# Altium schematic sheet Arak-ADC.SchDoc
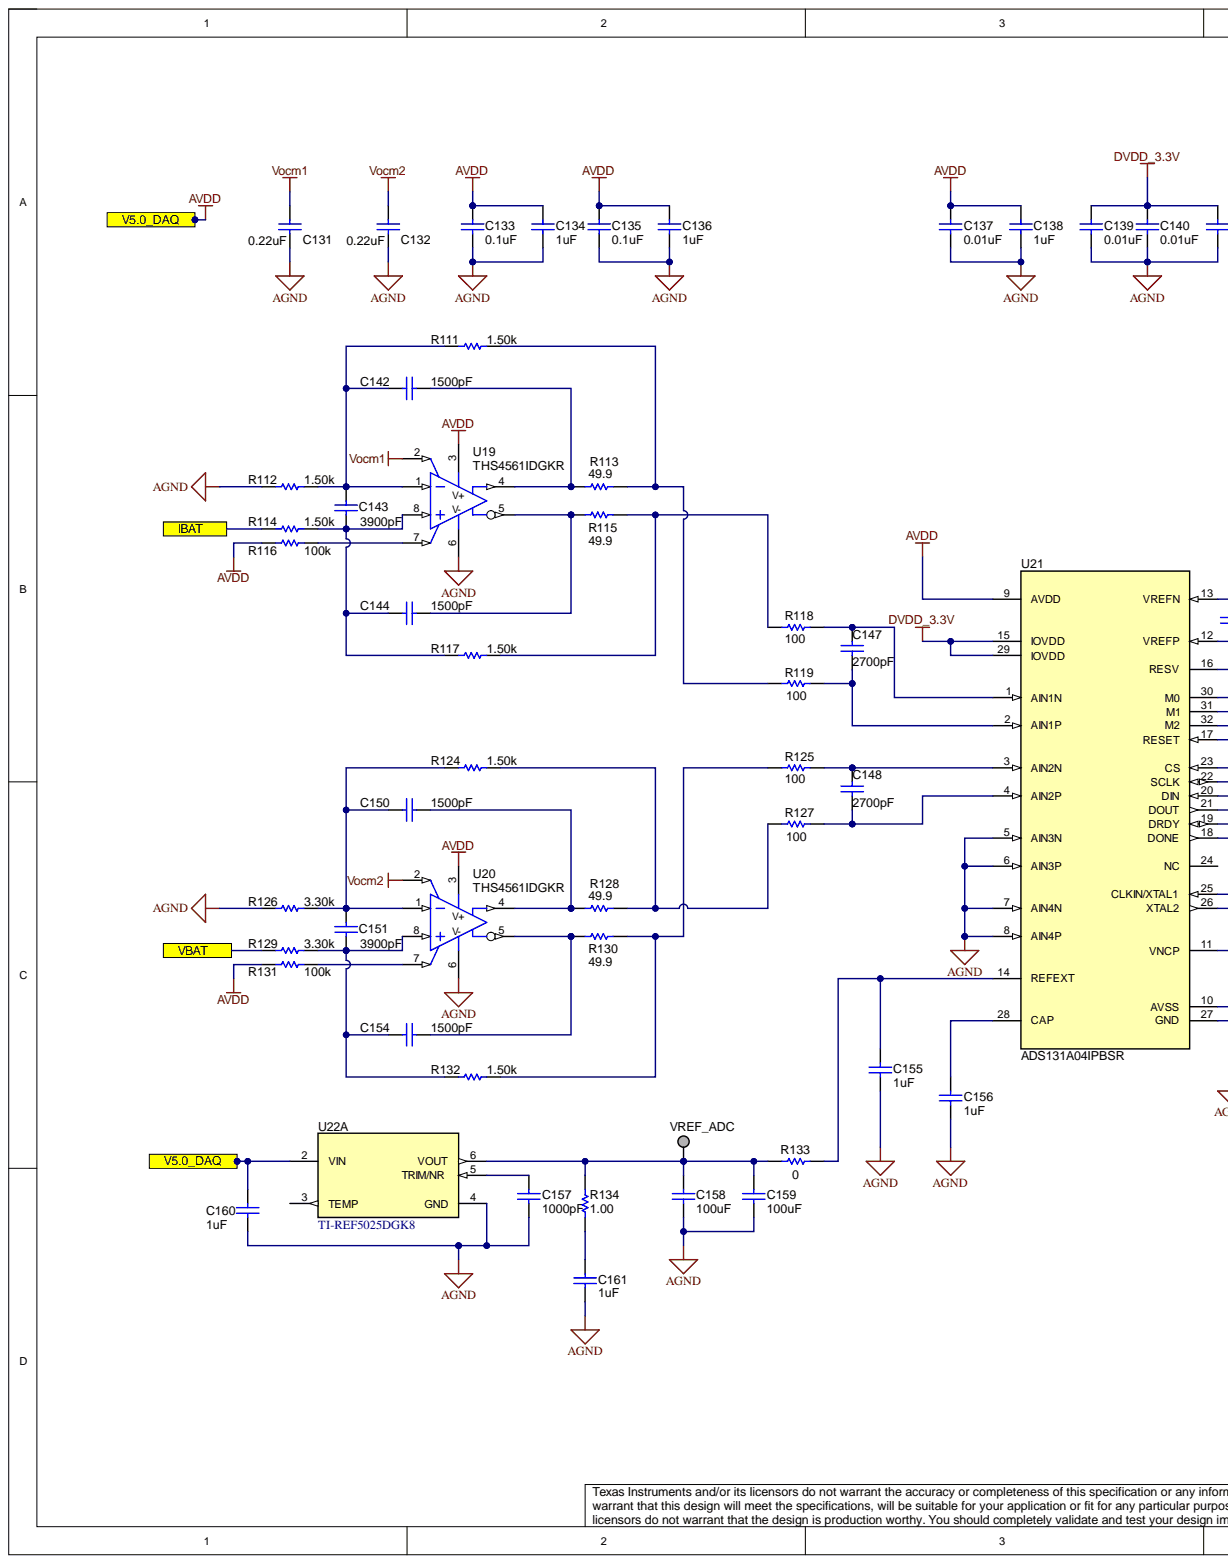
[diagram p0: Arak-ADC.SchDoc, left]
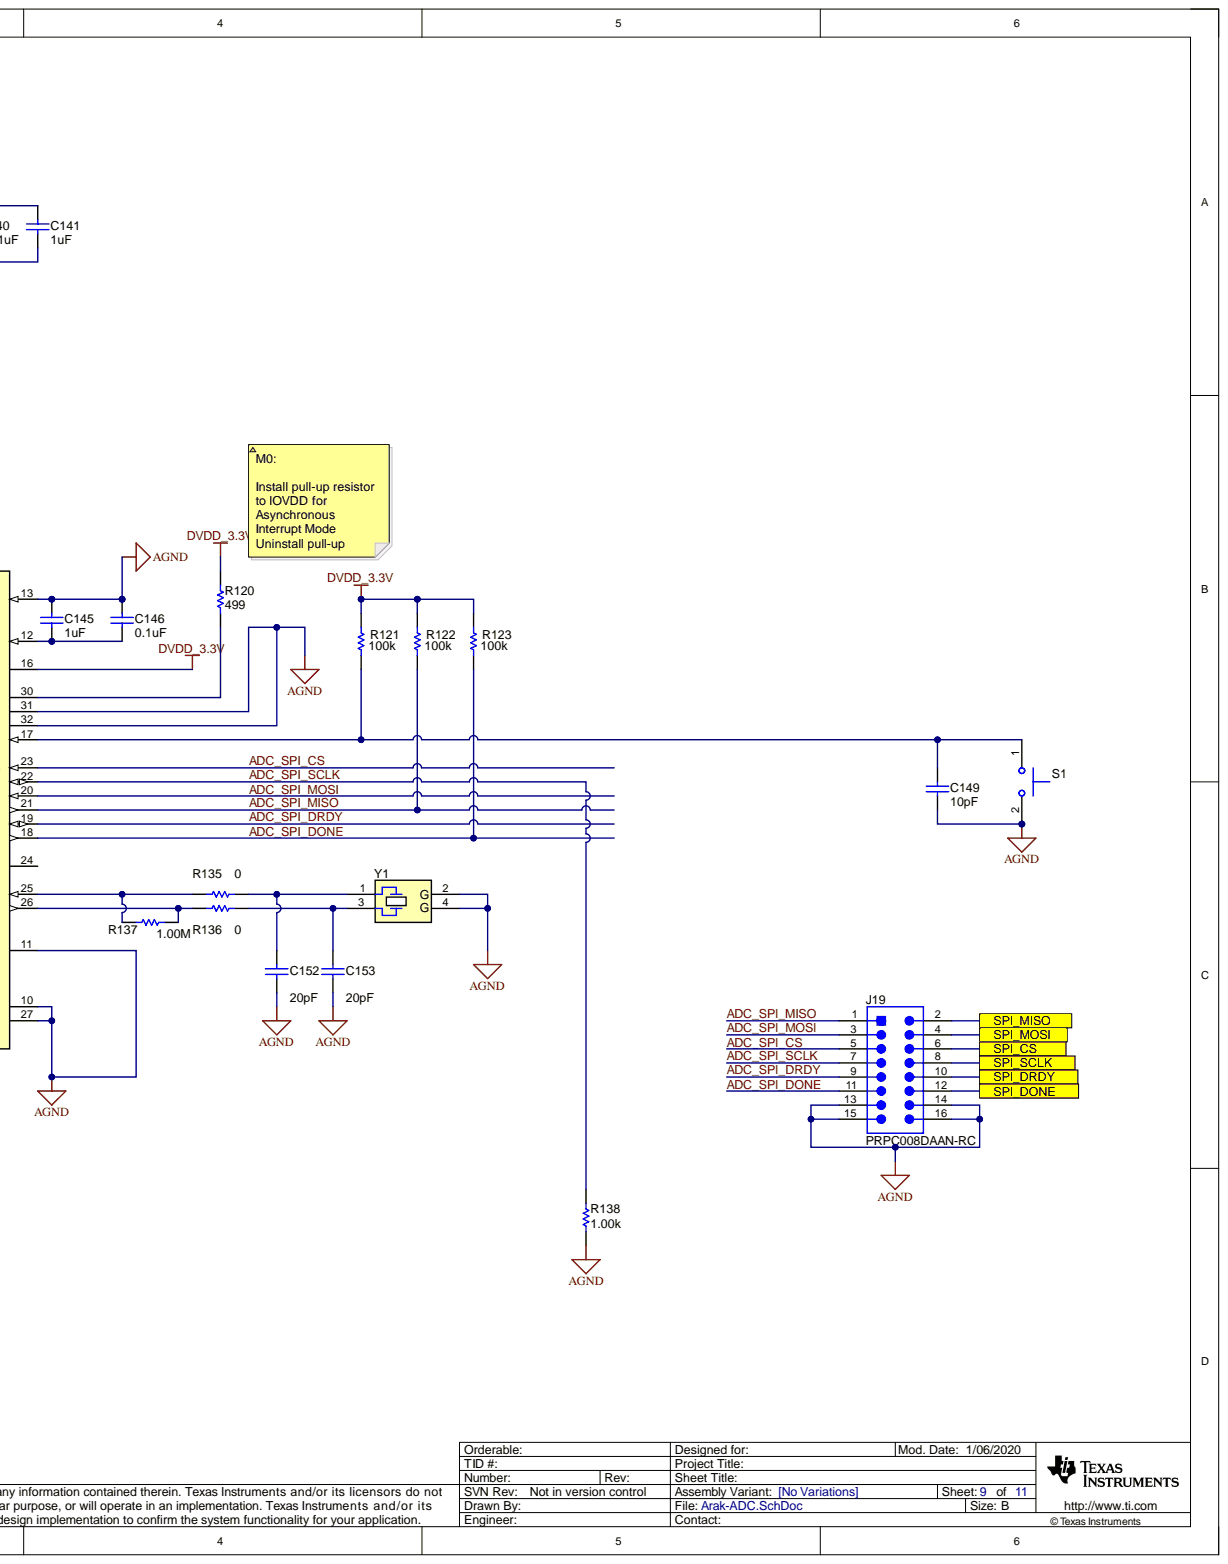
[diagram p1: Arak-ADC.SchDoc, right]

## Parts
C131  value 0.22uF  [0603]  (hidden comment: Capacitor)
C132  value 0.22uF  [0603]  (hidden comment: Capacitor)
C133  value 0.1uF  [0603]  (hidden comment: Capacitor)
C134  value 1uF  [0603]  (hidden comment: Capacitor)
C135  value 0.1uF  [0603]  (hidden comment: Capacitor)
C136  value 1uF  [0603]  (hidden comment: Capacitor)
C137  value 0.01uF  [0603]  (hidden comment: Capacitor)
C138  value 1uF  [0603]  (hidden comment: Capacitor)
C139  value 0.01uF  [0603]  (hidden comment: Capacitor)
C140  value 0.01uF  [0603]  (hidden comment: Capacitor)
C141  value 1uF  [0603]  (hidden comment: Capacitor)
C142  value 1500pF  [0603]  (hidden comment: Capacitor)
C143  value 3900pF  [0603]  (hidden comment: GRM188R72A392KA01D)
C144  value 1500pF  [0603]  (hidden comment: Capacitor)
C145  value 1uF  [0603]  (hidden comment: Capacitor)
C146  value 0.1uF  [0603]  (hidden comment: Capacitor)
C147  value 2700pF  [0603]  (hidden comment: Capacitor)
C148  value 2700pF  [0603]  (hidden comment: Capacitor)
C149  value 10pF  Cap_F: 10pF  [0603]  (hidden comment: Capacitor)
C150  value 1500pF  [0603]  (hidden comment: Capacitor)
C151  value 3900pF  [0603]  (hidden comment: GRM188R72A392KA01D)
C152  value 20pF  Cap_F: 20pF  [0603]  (hidden comment: GRM1885C1H200JA01D)
C153  value 20pF  Cap_F: 20pF  [0603]  (hidden comment: GRM1885C1H200JA01D)
C154  value 1500pF  [0603]  (hidden comment: Capacitor)
C155  value 1uF  [0603]  (hidden comment: Capacitor)
C156  value 1uF  [0603]  (hidden comment: Capacitor)
C157  value 1000pF  [0603]  (hidden comment: Capacitor)
C158  value 100uF  [1206_190]  (hidden comment: Capacitor)
C159  value 100uF  [1206_190]  (hidden comment: Capacitor)
C160  value 1uF  [0603]  (hidden comment: Capacitor)
C161  value 1uF  [0603]  (hidden comment: Capacitor)
J19  PRPC008DAAN-RC  [Sullins_xxxC008DAAN]
R111  value 1.50k  [0603]  (hidden comment: RC0603FR-071K5L)
R112  value 1.50k  [0603]  (hidden comment: RC0603FR-071K5L)
R113  value 49.9  Res_ohm: 49.9  [0603]  (hidden comment: Resistor)
R114  value 1.50k  [0603]  (hidden comment: RC0603FR-071K5L)
R115  value 49.9  Res_ohm: 49.9  [0603]  (hidden comment: Resistor)
R116  value 100k  Res_ohm: 100k  [0603]  (hidden comment: Resistor)
R117  value 1.50k  [0603]  (hidden comment: RC0603FR-071K5L)
R118  value 100  [0603]  (hidden comment: Resistor)
R119  value 100  [0603]  (hidden comment: Resistor)
R120  value 499  [0603]  (hidden comment: Resistor)
R121  value 100k  Res_ohm: 100k  [0603]  (hidden comment: Resistor)
R122  value 100k  Res_ohm: 100k  [0603]  (hidden comment: Resistor)
R123  value 100k  Res_ohm: 100k  [0603]  (hidden comment: Resistor)
R124  value 1.50k  [0603]  (hidden comment: RC0603FR-071K5L)
R125  value 100  [0603]  (hidden comment: Resistor)
R126  value 3.30k  [0603]  (hidden comment: RC0603FR-073K3L)
R127  value 100  [0603]  (hidden comment: Resistor)
R128  value 49.9  Res_ohm: 49.9  [0603]  (hidden comment: Resistor)
R129  value 3.30k  [0603]  (hidden comment: RC0603FR-073K3L)
R130  value 49.9  Res_ohm: 49.9  [0603]  (hidden comment: Resistor)
R131  value 100k  Res_ohm: 100k  [0603]  (hidden comment: Resistor)
R132  value 1.50k  [0603]  (hidden comment: RC0603FR-071K5L)
R133  value 0  [0603]  (hidden comment: Resistor)
R134  value 1.00  [0603]  (hidden comment: Resistor)
R135  value 0  [0603]  (hidden comment: Resistor)
R136  value 0  [0603]  (hidden comment: Resistor)
R137  ValueDisplayed: 1.00M  [0603]  (hidden comment: Resistor)
R138  value 1.00k  [0603]  (hidden comment: Resistor)
S1  Switch_PushButton  [SW_TL1015AF160QG]  (value not drawn)
U19  THS4561IDGKR  [DGK0008A_N]
U20  THS4561IDGKR  [DGK0008A_N]
U21  ADS131A04IPBSR  [PBS0032A_L]
U22  TI-REF5025DGK8  [DGK0008A_N]  (part A)
VREF_ADC  Pogo Pad  [PogoPad]  (value not drawn)  (no BOM)
Y1  XTAL_4P_13_G24  [CTS_407]  (value not drawn)

## Ports
IBAT, SPI_CS, SPI_DONE, SPI_DRDY, SPI_MISO, SPI_MOSI, SPI_SCLK, V5.0_DAQ, VBAT

## Nets
AGND: C131.2 C132.2 C133.2 C134.2 C135.2 C136.2 C137.2 C138.2 C139.2 C140.2 C141.2 C145.1 C146.1 C149.1 C152.2 C153.2 C155.2 C156.2 C157.2 C158.2 C159.2 C160.2 C161.2 J19.13 J19.14 J19.15 J19.16 R112.1 R126.1 R138.1 S1.2 U19.6(V-) U20.6(V-) U21.5(AIN3N) U21.6(AIN3P) U21.7(AIN4N) U21.8(AIN4P) U21.10(AVSS) U21.11(VNCP) U21.13(VREFN) U21.27(GND) U21.31(M1) U21.32(M2) U22.4(GND) Y1.2(G) Y1.4(G)
DVDD_3.3V: C139.1 C140.1 C141.1 R120.2 R121.2 R122.2 R123.2 U21.15(IOVDD) U21.16(RESV) U21.29(IOVDD)
Vocm1: C131.1 U19.2
Vocm2: C132.1 U20.2
ADC_SPI_CS: J19.5 U21.23(CS)
ADC_SPI_DONE: J19.11 R123.1 U21.18(DONE)
ADC_SPI_DRDY: J19.9 U21.19(DRDY)
ADC_SPI_MISO: J19.1 R122.1 U21.21(DOUT)
ADC_SPI_MOSI: J19.3 U21.20(DIN)
ADC_SPI_SCLK: J19.7 R138.2 U21.22(SCLK)
AVDD: C133.1 C134.1 C135.1 C136.1 C137.1 C138.1 C160.1 R116.2 R131.2 U19.3(V+) U20.3(V+) U21.9(AVDD) U22.2(VIN) ; port V5.0_DAQ
IBAT: R114.1 ; port IBAT
SPI_CS: J19.6 ; port SPI_CS
SPI_DONE: J19.12 ; port SPI_DONE
SPI_DRDY: J19.10 ; port SPI_DRDY
SPI_MISO: J19.2 ; port SPI_MISO
SPI_MOSI: J19.4 ; port SPI_MOSI
SPI_SCLK: J19.8 ; port SPI_SCLK
VBAT: R129.1 ; port VBAT
NetC142_1: C142.1 C143.1 R111.1 R112.2 U19.1
NetC142_2: C142.2 R113.1 U19.4
NetC143_2: C143.2 C144.1 R114.2 R117.1 U19.8
NetC144_2: C144.2 R115.1 U19.5
NetC145_2: C145.2 C146.2 U21.12(VREFP)
NetC147_1: C147.1 R118.2 U21.1(AIN1N)
NetC147_2: C147.2 R119.2 U21.2(AIN1P)
NetC148_1: C148.1 R125.2 U21.3(AIN2N)
NetC148_2: C148.2 R127.2 U21.4(AIN2P)
NetC149_2: C149.2 R121.1 S1.1 U21.17(RESET)
NetC150_1: C150.1 C151.1 R124.1 R126.2 U20.1
NetC150_2: C150.2 R128.1 U20.4
NetC151_2: C151.2 C154.1 R129.2 R132.1 U20.8
NetC152_1: C152.1 R135.2 Y1.1
NetC153_1: C153.1 R136.1 Y1.3
NetC154_2: C154.2 R130.1 U20.5
NetC155_1: C155.1 R133.2 U21.14(REFEXT)
NetC156_1: C156.1 U21.28(CAP)
NetC157_1: C157.1 U22.5(TRIM/NR)
NetC158_1: C158.1 C159.1 R133.1 R134.2 U22.6(VOUT) VREF_ADC.1
NetC161_1: C161.1 R134.1
NetR111_2: R111.2 R113.2 R119.1
NetR115_2: R115.2 R117.2 R118.1
NetR116_1: R116.1 U19.7
NetR120_1: R120.1 U21.30(M0)
NetR124_2: R124.2 R127.1 R128.2
NetR125_1: R125.1 R130.2 R132.2
NetR131_1: R131.1 U20.7
NetR135_1: R135.1 R137.2 U21.25(CLKIN/XTAL1)
NetR136_2: R136.2 R137.1 U21.26(XTAL2)

Not connected: U21 24(NC); U22 3(TEMP)

## Library data of the parts
C131 C132 = CMP-0006903-4: CAP, CERM, 0.22 uF, 16 V, +/- 10%, X7R, 0603; Manufacturer: Kemet; PartNumber: C0603C224K4RACTU; AEC Category: Q200; Cap_F: 220nF; Polarized: N; SizeArea_mm2: 1.28; SizeAreaWB_mm2: 4.68; SizeX_mm: 1.6; SizeY_mm: 0.8; SizeZ_mm: 1; TaMax_C: 125°C; TaMin_C: -55°C; U/M: EA; Value1: Unknown; Value2: 16V; AEC Qualified: ?; Cap_Case: 0603; Component Kind: Standard; ComponentLink1Description: Datasheet link; ComponentLink1URL: http://www.kemet.com/kemet/web/homepage/kechome.nsf/vapubfiles/F3102_Y5V.pdf/$file/F3102_Y5V.pdf; CompType: Capacitor; LatestRevisionDate: 2017-03-09; Library Name: Altium Vault - TI; MountType: SMT; PackageReference: 0603; Published: 2008-06-19; RoHS: Y; Supplier 1: Digi-Key; Supplier 2: Mouser; Supplier 3: Farnell; Supplier Part Number 1: 399-5619-1-ND; Supplier Part Number 2: 80-C0603C224K4R; Supplier Part Number 3: 1650007; Technology: Ceramic; TempCo: X7R; Tolerance_%: 10%; ValueDisplayed: 0.22uF; VDC_V: 16V; Publisher: Texas Instruments
C133 C135 C146 = CMP-0006752-5: CAP, CERM, 0.1 uF, 25 V, +/- 5%, X7R, 0603; Manufacturer: AVX; PartNumber: 06033C104JAT2A; AEC Category: Q200; AEC Qualified: N; Cap_F: 100nF; ESR_ohm: 0.05; SizeArea_mm2: 1.28; SizeAreaWB_mm2: 4.68; SizeX_mm: 1.6; SizeY_mm: 0.8; SizeZ_mm: 1; TaMax_C: 125°C; TaMin_C: -55°C; U/M: EA; Value1: 0.05 ohm; Value2: 25V; AEC Grade: N/A; Cap_Case: 0603; Component Kind: Standard; ComponentLink1Description: Datasheet link; ComponentLink1URL: http://datasheets.avx.com/X7RDielectric.pdf; CompType: Capacitor; LatestRevisionDate: 2017-03-09; Library Name: Altium Vault - TI; MountType: SMT; PackageReference: 0603; Polarized: N; Published: 2008-06-19; RoHS: Y; Supplier 1: Digi-Key; Supplier 2: Mouser; Supplier 3: Farnell; Supplier Part Number 1: 478-3713-1-ND; Supplier Part Number 2: 581-06033C104JAT2A; Supplier Part Number 3: 1740614; Technology: Ceramic; TempCo: X7R; Tolerance_%: 5%; ValueDisplayed: 0.1uF; VDC_V: 25V; Publisher: Texas Instruments
C134 C136 C138 C141 C145 C155 C156 C160 C161 = CMP-0007405-4: CAP, CERM, 1 uF, 25 V, +/- 10%, X7R, 0603; Manufacturer: MuRata; PartNumber: GRM188R71E105KA12D; AEC Category: Q200; Cap_F: 1µF; Polarized: N; SizeArea_mm2: 1.28; SizeAreaWB_mm2: 4.68; SizeX_mm: 1.6; SizeY_mm: 0.8; SizeZ_mm: 1; TaMax_C: 125°C; TaMin_C: -55°C; U/M: EA; Value1: Unknown; Value2: 25V; AEC Qualified: ?; Cap_Case: 0603; Component Kind: Standard; ComponentLink1Description: Datasheet link; ComponentLink1URL: http://search.murata.co.jp/Ceramy/PnsearchViewAction.do?HnOFG=ON&HnNFG=ON&SEL_CNT=50&sLang=en&sKey=GRM188R71E105KA12D&x=9&y=9&search=startwith; CompType: Capacitor; LatestRevisionDate: 2017-03-09; Library Name: Altium Vault - TI; MountType: SMT; PackageReference: 0603; Published: 2008-06-19; RoHS: Y; Supplier 1: Digi-Key; Supplier 2: Mouser; Supplier 3: Farnell; Supplier Part Number 1: 490-5307-1-ND; Supplier Part Number 2: 81-GRM188R71E105KA2D; Supplier Part Number 3: 1735540; Technology: Ceramic; TempCo: X7R; Tolerance_%: 10%; ValueDisplayed: 1uF; VDC_V: 25V; Publisher: Texas Instruments
C137 C139 C140 = CMP-0006727-4: CAP, CERM, 0.01 uF, 100 V, +/- 10%, X7R, 0603; Manufacturer: AVX; PartNumber: 06031C103KAT2A; AEC Category: Q200; AEC Qualified: N; Cap_F: 10nF; ESR_ohm: 0.098; SizeArea_mm2: 1.28; SizeAreaWB_mm2: 4.68; SizeX_mm: 1.6; SizeY_mm: 0.8; SizeZ_mm: 1; TaMax_C: 125°C; TaMin_C: -55°C; U/M: EA; Value1: 0.098 ohm; Value2: 100V; AEC Grade: N/A; Cap_Case: 0603; Component Kind: Standard; ComponentLink1Description: Datasheet link; ComponentLink1URL: http://datasheets.avx.com/X7RDielectric.pdf; CompType: Capacitor; LatestRevisionDate: 2017-03-09; Library Name: Altium Vault - TI; MountType: SMT; PackageReference: 0603; Polarized: N; Published: 2008-06-19; RoHS: Y; Supplier 1: Digi-Key; Supplier 2: Newark; Supplier 3: Mouser; Supplier Part Number 1: 478-1205-1-ND; Supplier Part Number 2: 96K4758; Supplier Part Number 3: 581-06031C103K; Technology: Ceramic; TempCo: X7R; Tolerance_%: 10%; ValueDisplayed: 0.01uF; VDC_V: 100V; Publisher: Texas Instruments
C142 C144 C150 C154 = CMP-0006732-4: CAP, CERM, 1500 pF, 100 V, +/- 5%, X7R, 0603; Manufacturer: AVX; PartNumber: 06031C152JAT2A; AEC Category: Q200; AEC Qualified: N; Cap_F: 1.5nF; ESR_ohm: 2.29d; SizeArea_mm2: 1.28; SizeAreaWB_mm2: 4.68; SizeX_mm: 1.6; SizeY_mm: 0.8; SizeZ_mm: 1; TaMax_C: 125°C; TaMin_C: -55°C; U/M: EA; Value1: 0.229 ohm; Value2: 100V; AEC Grade: N/A; Cap_Case: 0603; Component Kind: Standard; ComponentLink1Description: Datasheet link; ComponentLink1URL: http://datasheets.avx.com/X7RDielectric.pdf; CompType: Capacitor; LatestRevisionDate: 2017-03-09; Library Name: Altium Vault - TI; MountType: SMT; PackageReference: 0603; Polarized: N; Published: 2008-06-19; RoHS: Y; Supplier 1: Digi-Key; Supplier 2: Newark; Supplier 3: Mouser; Supplier Part Number 1: 478-3703-1-ND; Supplier Part Number 2: 96M1240; Supplier Part Number 3: 581-06031C152JAT2A; Technology: Ceramic; TempCo: X7R; Tolerance_%: 5%; ValueDisplayed: 1500pF; VDC_V: 100V; Publisher: Texas Instruments
C143 C151 = CMP-0007481-5: CAP, CERM, 3900 pF, 100 V, +/- 10%, X7R, 0603; Manufacturer: MuRata; AEC Category: Q200; Cap_F: 3.9nF; CompType: Capacitor; Polarized: N; SizeArea_mm2: 1.28; SizeAreaWB_mm2: 4.68; SizeX_mm: 1.6; SizeY_mm: 0.8; SizeZ_mm: 1; TaMax_C: 125°C; TaMin_C: -55°C; U/M: EA; Value1: Unknown; Value2: 100V; AEC Qualified: ?; Cap_Case: 0603; Component Kind: Standard; ComponentLink1Description: Datasheet link; ComponentLink1URL: http://search.murata.co.jp/Ceramy/PnsearchViewAction.do?HnOFG=ON&HnNFG=ON&SEL_CNT=50&sLang=en&sKey=GRM188R72A392KA01D&x=9&y=9&search=startwith; LatestRevisionDate: 2017-03-09; Library Name: Altium Vault - TI; MountType: SMT; PackageReference: 0603; PartNumber: GRM188R72A392KA01D; Published: 2008-06-19; RoHS: Y; Supplier 1: Digi-Key; Supplier 2: Mouser; Supplier Part Number 1: GRM188R72A392KA01D-ND; Supplier Part Number 2: 81-GRM188R72A392KA1D; Technology: Ceramic; TempCo: X7R; Tolerance_%: 10%; ValueDisplayed: 3900pF; VDC_V: 100V; Publisher: Texas Instruments
C147 C148 = CMP-0007204-4: CAP, CERM, 2700 pF, 50 V, +/- 5%, C0G/NP0, 0603; Manufacturer: MuRata; PartNumber: GRM1885C1H272JA01D; AEC Category: Q200; Cap_F: 2.7nF; Polarized: N; SizeArea_mm2: 1.28; SizeAreaWB_mm2: 4.68; SizeX_mm: 1.6; SizeY_mm: 0.8; SizeZ_mm: 1; TaMax_C: 125°C; TaMin_C: -55°C; U/M: EA; Value1: Unknown; Value2: 50V; AEC Qualified: ?; Cap_Case: 0603; Component Kind: Standard; ComponentLink1Description: Datasheet link; ComponentLink1URL: http://search.murata.co.jp/Ceramy/PnsearchViewAction.do?HnOFG=ON&HnNFG=ON&SEL_CNT=50&sLang=en&sKey=GRM1885C1H272JA01D&x=9&y=9&search=startwith; CompType: Capacitor; LatestRevisionDate: 2017-03-09; Library Name: Altium Vault - TI; MountType: SMT; PackageReference: 0603; Published: 2008-06-19; RoHS: Y; Supplier 1: Digi-Key; Supplier 2: Mouser; Supplier Part Number 1: 490-3283-1-ND; Supplier Part Number 2: 81-GRM185C1H272JA01D; Technology: Ceramic; TempCo: C0G/NP0; Tolerance_%: 5%; ValueDisplayed: 2700pF; VDC_V: 50V; Publisher: Texas Instruments
C149 = CMP-0006761-4: CAP, CERM, 10 pF, 50 V, +/- 5%, C0G/NP0, 0603; Manufacturer: AVX; PartNumber: 06035A100JAT2A; AEC Category: Q200; AEC Qualified: N; ESR_ohm: 4.03d; SizeArea_mm2: 1.28; SizeAreaWB_mm2: 4.68; SizeX_mm: 1.6; SizeY_mm: 0.8; SizeZ_mm: 1; TaMax_C: 125°C; TaMin_C: -55°C; U/M: EA; Value1: 0.403 ohm; Value2: 50V; AEC Grade: N/A; Cap_Case: 0603; Component Kind: Standard; ComponentLink1Description: Datasheet link; ComponentLink1URL: http://datasheets.avx.com/C0GNP0-Dielectric.pdf; CompType: Capacitor; LatestRevisionDate: 2017-03-09; Library Name: Altium Vault - TI; MountType: SMT; PackageReference: 0603; Polarized: N; Published: 2008-06-19; RoHS: Y; Supplier 1: Digi-Key; Supplier 2: Newark; Supplier 3: Mouser; Supplier Part Number 1: 478-1163-1-ND; Supplier Part Number 2: 17C6435; Supplier Part Number 3: 581-06035A100J; Technology: Ceramic; TempCo: C0G/NP0; Tolerance_%: 5%; ValueDisplayed: 10pF; VDC_V: 50V; Publisher: Texas Instruments
C152 C153 = CMP-0007193-4: CAP, CERM, 20 pF, 50 V, +/- 5%, C0G/NP0, 0603; Manufacturer: MuRata; AEC Category: Q200; CompType: Capacitor; Polarized: N; SizeArea_mm2: 1.28; SizeAreaWB_mm2: 4.68; SizeX_mm: 1.6; SizeY_mm: 0.8; SizeZ_mm: 1; TaMax_C: 125°C; TaMin_C: -55°C; U/M: EA; Value1: Unknown; Value2: 50V; AEC Qualified: ?; Cap_Case: 0603; Component Kind: Standard; ComponentLink1Description: Datasheet link; ComponentLink1URL: http://search.murata.co.jp/Ceramy/PnsearchViewAction.do?HnOFG=ON&HnNFG=ON&SEL_CNT=50&sLang=en&sKey=GRM1885C1H200JA01D&x=9&y=9&search=startwith; LatestRevisionDate: 2017-03-09; Library Name: Altium Vault - TI; MountType: SMT; PackageReference: 0603; PartNumber: GRM1885C1H200JA01D; Published: 2008-06-19; RoHS: Y; Supplier 1: Digi-Key; Supplier 2: Mouser; Supplier Part Number 1: 490-1410-1-ND; Supplier Part Number 2: 81-GRM39C200J50; Technology: Ceramic; TempCo: C0G/NP0; Tolerance_%: 5%; ValueDisplayed: 20pF; VDC_V: 50V; Publisher: Texas Instruments
C157 = CMP-0006723-4: CAP, CERM, 1000 pF, 100 V, +/- 5%, X7R, 0603; Manufacturer: AVX; PartNumber: 06031C102JAT2A; AEC Category: Q200; AEC Qualified: N; Cap_F: 1nF; ESR_ohm: 2.76d; SizeArea_mm2: 1.28; SizeAreaWB_mm2: 4.68; SizeX_mm: 1.6; SizeY_mm: 0.8; SizeZ_mm: 1; TaMax_C: 125°C; TaMin_C: -55°C; U/M: EA; Value1: 0.276 ohm; Value2: 100V; AEC Grade: N/A; Cap_Case: 0603; Component Kind: Standard; ComponentLink1Description: Datasheet link; ComponentLink1URL: http://datasheets.avx.com/X7RDielectric.pdf; CompType: Capacitor; LatestRevisionDate: 2017-03-09; Library Name: Altium Vault - TI; MountType: SMT; PackageReference: 0603; Polarized: N; Published: 2008-06-19; RoHS: Y; Supplier 1: Digi-Key; Supplier 2: Newark; Supplier 3: Mouser; Supplier Part Number 1: 478-3698-1-ND; Supplier Part Number 2: 96M1234; Supplier Part Number 3: 581-06031C102J; Technology: Ceramic; TempCo: X7R; Tolerance_%: 5%; ValueDisplayed: 1000pF; VDC_V: 100V; Publisher: Texas Instruments
C158 C159 = CMP-0008649-2: CAP, CERM, 100 uF, 10 V, +/- 20%, X5R, 1206_190; Manufacturer: TDK; PartNumber: C3216X5R1A107M160AC; AEC Category: Q200; AEC Qualified: N; Cap_F: 100µF; ESR_ohm: 2.838m; IRMS_A: 4.30694A; SizeArea_mm2: 5.12; SizeAreaWB_mm2: 10.92; SizeX_mm: 3.2; SizeY_mm: 1.6; SizeZ_mm: 1.9; TaMax_C: 85°C; TaMin_C: -55°C; U/M: EA; Value1: 0.002838 ohm; Value2: 10V; AEC Grade: N/A; Cap_Case: 1206_190; Component Kind: Standard; ComponentLink1Description: Datasheet link; ComponentLink1URL: https://product.tdk.com/en/search/capacitor/ceramic/mlcc/info?part_no=C3216X5R1A107M160AC; CompType: Capacitor; LatestRevisionDate: 2017-03-09; Library Name: Altium Vault - TI; MountType: SMT; PackageReference: 1206_190; Polarized: N; Published: 2008-06-19; RoHS: Y; Supplier 1: Digi-Key; Supplier 2: Newark; Supplier 3: Mouser; Supplier Part Number 1: 445-6007-1-ND; Supplier Part Number 2: 12T1945; Supplier Part Number 3: 810-C3216X5R1A107M; Technology: Ceramic; TempCo: X5R; Tolerance_%: 20%; ValueDisplayed: 100uF; VDC_V: 10V; Publisher: Texas Instruments
J19 = CMP-0054590-1: Header, 2.54mm, 8x2, Gold, TH; Manufacturer: Sullins Connector Solutions; CNHeader: TRUE; CT: FALSE; Gender: Male; Pitch_mm: 2.54; Row_Count: 2; Shrouded: N; ClassName: Header; Color: Black; Component Kind: Standard; ComponentLink1Description: Datasheet URL; ComponentLink1URL: http://sullinscorp.com/drawings/294___R__C_____D___N-RC,_11636.pdf; CompType: Header; ContactFinish: Gold; LatestRevisionDate: 26/05/2017; Library Name: Altium Vault - TI; MountType: TH; Orientation: Vertical; PackageReference: Header, 2.54mm, 8x2, TH; PartNumber: PRPC008DAAN-RC; PinCount: 16; RoHS: Y; RowSpacing_mm: 2.54; Supplier 1: Digi-Key; Supplier Part Number 1: S2011EC-08-ND; Publisher: Texas Instruments
R111 R112 R114 R117 R124 R132 = CMP-0022883-3: RES, 1.50 k, 1%, 0.1 W, 0603; Manufacturer: Yageo America; AEC Category: Q200; CompType: Resistor; EIA_Code: E96; Power_W: 100mW; Res_ohm: 1.5k; SizeArea_mm2: 1.28; SizeX_mm: 1.6; SizeY_mm: 0.8; SizeZ_mm: 1; TempCo: 200 ppm/C; U/M: EA; Value2: 0.1W; Value_Code: 1k50; VDC_V: 150V; AEC Qualified: ?; Component Kind: Standard; ComponentLink1Description: Datasheet link; ComponentLink1URL: http://www.yageo.com/pdf/Pu-RC0603_51_PbFree_L_2.pdf; LatestRevisionDate: 2017-03-09; Library Name: Altium Vault - TI; MountType: SMT; PackageReference: 0603; PartNumber: RC0603FR-071K5L; Published: 2008-06-26; RoHS: Y; Supplier 1: Digi-Key; Supplier 2: Mouser; Supplier 3: Farnell; Supplier Part Number 1: 311-1.50KHRCT-ND; Supplier Part Number 2: 603-RC0603FR-071K5L; Supplier Part Number 3: 9238506; Technology: Thick Film; Tolerance_%: 1%; Value1: 1%; ValueDisplayed: 1.50k; Publisher: Texas Instruments
R113 R115 R128 R130 = CMP-0022448-4: RES, 49.9, 1%, 0.1 W, AEC-Q200 Grade 0, 0603; Manufacturer: Vishay-Dale; PartNumber: CRCW060349R9FKEA; AEC Category: Q200; AEC Grade: 0; AEC Qualified: Y; EIA_Code: E96; Power_W: 100mW; SizeArea_mm2: 1.28; SizeX_mm: 1.6; SizeY_mm: 0.8; SizeZ_mm: 1; TempCo: 100 ppm/C; Value2: 0.1W; Value_Code: 49R9; VDC_V: 75V; Component Kind: Standard; ComponentLink1Description: Datasheet link; ComponentLink1URL: http://www.vishay.com/docs/20035/dcrcwe3.pdf; CompType: Resistor; Library Name: Altium Vault - TI; MountType: SMT; PackageReference: 0603; RoHS: E; Supplier 1: Digi-Key; Supplier 2: Newark; Supplier 3: Mouser; Supplier Part Number 1: 541-49.9HCT-ND; Supplier Part Number 2: 52K8566; Supplier Part Number 3: 71-CRCW0603-49.9-E3; Technology: Thick Film; Tolerance_%: 1%; Value1: 1%; ValueDisplayed: 49.9
R116 R121-R123 R131 = CMP-0021990-4: RES, 100 k, 1%, 0.1 W, AEC-Q200 Grade 0, 0603; Manufacturer: Vishay-Dale; PartNumber: CRCW0603100KFKEA; AEC Category: Q200; AEC Grade: 0; AEC Qualified: Y; EIA_Code: E96; Power_W: 100mW; SizeArea_mm2: 1.28; SizeX_mm: 1.6; SizeY_mm: 0.8; SizeZ_mm: 1; TempCo: 100 ppm/C; Value2: 0.1W; VDC_V: 75V; Component Kind: Standard; ComponentLink1Description: Datasheet link; ComponentLink1URL: http://www.vishay.com/docs/20035/dcrcwe3.pdf; CompType: Resistor; Library Name: Altium Vault - TI; MountType: SMT; PackageReference: 0603; RoHS: E; Supplier 1: Digi-Key; Supplier 2: Newark; Supplier 3: Mouser; Supplier Part Number 1: 541-100KHCT-ND; Supplier Part Number 2: 42K3668; Supplier Part Number 3: 71-CRCW0603-100K-E3; Technology: Thick Film; Tolerance_%: 1%; Value1: 1%; Value_Code: 100k; ValueDisplayed: 100k
R118 R119 R125 R127 = CMP-0021991-4: RES, 100, 1%, 0.1 W, AEC-Q200 Grade 0, 0603; Manufacturer: Vishay-Dale; PartNumber: CRCW0603100RFKEA; AEC Category: Q200; AEC Grade: 0; AEC Qualified: Y; EIA_Code: E96; Power_W: 100mW; SizeArea_mm2: 1.28; SizeX_mm: 1.6; SizeY_mm: 0.8; SizeZ_mm: 1; TempCo: 100 ppm/C; Value2: 0.1W; Value_Code: 100R; VDC_V: 75V; Component Kind: Standard; ComponentLink1Description: Datasheet link; ComponentLink1URL: http://www.vishay.com/docs/20035/dcrcwe3.pdf; CompType: Resistor; Library Name: Altium Vault - TI; MountType: SMT; PackageReference: 0603; Res_ohm: 100; RoHS: E; Supplier 1: Digi-Key; Supplier 2: Newark; Supplier 3: Mouser; Supplier Part Number 1: 541-100HCT-ND; Supplier Part Number 2: 52K8078; Supplier Part Number 3: 71-CRCW0603-100-E3; Technology: Thick Film; Tolerance_%: 1%; Value1: 1%; ValueDisplayed: 100
R120 = CMP-0022446-5: RES, 499, 1%, 0.1 W, AEC-Q200 Grade 0, 0603; Manufacturer: Vishay-Dale; PartNumber: CRCW0603499RFKEA; AEC Category: Q200; AEC Grade: 0; AEC Qualified: Y; EIA_Code: E96; Power_W: 100mW; SizeArea_mm2: 1.28; SizeX_mm: 1.6; SizeY_mm: 0.8; SizeZ_mm: 1; TempCo: 100 ppm/C; Value2: 0.1W; Value_Code: 499R; VDC_V: 75V; Component Kind: Standard; ComponentLink1Description: Datasheet link; ComponentLink1URL: http://www.vishay.com/docs/20035/dcrcwe3.pdf; CompType: Resistor; Library Name: Altium Vault - TI; MountType: SMT; PackageReference: 0603; Res_ohm: 499; RoHS: E; Supplier 1: Digi-Key; Supplier 2: Newark; Supplier 3: Mouser; Supplier Part Number 1: 541-499HCT-ND; Supplier Part Number 2: 52K8569; Supplier Part Number 3: 71-CRCW0603-499-E3; Technology: Thick Film; Tolerance_%: 1%; Value1: 1%; ValueDisplayed: 499
R126 R129 = CMP-0023081-3: RES, 3.30 k, 1%, 0.1 W, 0603; Manufacturer: Yageo America; AEC Category: Q200; CompType: Resistor; Power_W: 100mW; Res_ohm: 3.3k; SizeArea_mm2: 1.28; SizeX_mm: 1.6; SizeY_mm: 0.8; SizeZ_mm: 1; TempCo: 200 ppm/C; U/M: EA; Value2: 0.1W; Value_Code: 3k30; VDC_V: 150V; AEC Qualified: ?; Component Kind: Standard; ComponentLink1Description: Datasheet link; ComponentLink1URL: http://www.yageo.com/pdf/Pu-RC0603_51_PbFree_L_2.pdf; LatestRevisionDate: 2017-03-09; Library Name: Altium Vault - TI; MountType: SMT; PackageReference: 0603; PartNumber: RC0603FR-073K3L; Published: 2008-06-26; RoHS: Y; Supplier 1: Digi-Key; Supplier 2: Mouser; Supplier 3: Farnell; Supplier Part Number 1: 311-3.30KHRCT-ND; Supplier Part Number 2: 603-RC0603FR-073K3L; Supplier Part Number 3: 9238549; Technology: Thick Film; Tolerance_%: 1%; Value1: 1%; ValueDisplayed: 3.30k; Publisher: Texas Instruments
R133 R135 R136 = CMP-0025874-3: RES, 0, 5%, 0.1 W, 0603; Manufacturer: Panasonic; PartNumber: ERJ-3GEY0R00V; AEC Category: Q200; EIA_Code: E24; Power_W: 100mW; SizeArea_mm2: 1.28; SizeX_mm: 1.6; SizeY_mm: 0.8; SizeZ_mm: 1; TempCo: 600 ppm/C; U/M: EA; Value2: 0.1W; Value_Code: 0000; VDC_V: 50V; AEC Qualified: ?; Component Kind: Standard; ComponentLink1Description: Datasheet link; ComponentLink1URL: http://www.panasonic.com/industrial/components/pdf/AOA0000CE1.pdf; CompType: Resistor; LatestRevisionDate: 2017-03-09; Library Name: Altium Vault - TI; MountType: SMT; PackageReference: 0603; Published: 2008-06-26; Res_ohm: 0; RoHS: Y; Supplier 1: Digi-Key; Supplier 2: Mouser; Supplier 3: Farnell; Supplier Part Number 1: P0.0GCT-ND; Supplier Part Number 2: 667-ERJ-3GEY0R00V; Supplier Part Number 3: 2059527; Technology: Thick Film; Tolerance_%: 5%; Value1: 5%; ValueDisplayed: 0; Publisher: Texas Instruments
R134 = CMP-0022170-4: RES, 1.00, 1%, 0.1 W, AEC-Q200 Grade 0, 0603; Manufacturer: Vishay-Dale; PartNumber: CRCW06031R00FKEA; AEC Category: Q200; AEC Grade: 0; AEC Qualified: Y; EIA_Code: E96; Power_W: 100mW; Res_ohm: 1; SizeArea_mm2: 1.28; SizeX_mm: 1.6; SizeY_mm: 0.8; TempCo: 100 ppm/C; Value2: 0.1W; Value_Code: 1R00; VDC_V: 75V; Component Kind: Standard; ComponentLink1Description: Datasheet link; ComponentLink1URL: http://www.vishay.com/docs/20035/dcrcwe3.pdf; CompType: Resistor; Library Name: Altium Vault - TI; MountType: SMT; PackageReference: 0603; RoHS: E; SizeZ_mm: 1; Supplier 1: Newark; Supplier 2: Mouser; Supplier 3: Farnell; Supplier Part Number 1: 52K8059; Supplier Part Number 2: 71-CRCW06031R00FKEA; Supplier Part Number 3: 1616871; Technology: Thick Film; Tolerance_%: 1%; Value1: 1%; ValueDisplayed: 1.00
R137 = CMP-0022138-4: RES, 1.00 M, 1%, 0.1 W, AEC-Q200 Grade 0, 0603; Manufacturer: Vishay-Dale; PartNumber: CRCW06031M00FKEA; AEC Category: Q200; AEC Grade: 0; AEC Qualified: Y; EIA_Code: E96; Power_W: 100mW; Res_ohm: 1M; SizeArea_mm2: 1.28; SizeX_mm: 1.6; SizeY_mm: 0.8; SizeZ_mm: 1; TempCo: 100 ppm/C; Value: 1.00Meg; Value2: 0.1W; Value_Code: 1M00; VDC_V: 75V; Component Kind: Standard; ComponentLink1Description: Datasheet link; ComponentLink1URL: http://www.vishay.com/docs/20035/dcrcwe3.pdf; CompType: Resistor; Library Name: Altium Vault - TI; MountType: SMT; PackageReference: 0603; RoHS: E; Supplier 1: Digi-Key; Supplier 2: Newark; Supplier 3: Mouser; Supplier Part Number 1: 541-1.00MHCT-ND; Supplier Part Number 2: 52K8053; Supplier Part Number 3: 71-CRCW0603-1.0M-E3; Technology: Thick Film; Tolerance_%: 1%; Value1: 1%
R138 = CMP-0022109-5: RES, 1.00 k, 1%, 0.1 W, AEC-Q200 Grade 0, 0603; Manufacturer: Vishay-Dale; PartNumber: CRCW06031K00FKEA; AEC Category: Q200; AEC Grade: 0; AEC Qualified: Y; EIA_Code: E96; Power_W: 100mW; Res_ohm: 1k; SizeArea_mm2: 1.28; SizeX_mm: 1.6; SizeY_mm: 0.8; SizeZ_mm: 1; TempCo: 100 ppm/C; Value2: 0.1W; Value_Code: 1k00; VDC_V: 75V; Component Kind: Standard; ComponentLink1Description: Datasheet link; ComponentLink1URL: http://www.vishay.com/docs/20035/dcrcwe3.pdf; CompType: Resistor; Library Name: Altium Vault - TI; MountType: SMT; PackageReference: 0603; RoHS: E; Supplier 1: Digi-Key; Supplier 2: Newark; Supplier 3: Mouser; Supplier Part Number 1: 541-1.00KHCT-ND; Supplier Part Number 2: 52K8015; Supplier Part Number 3: 71-CRCW0603-1.0K-E3; Technology: Thick Film; Tolerance_%: 1%; Value1: 1%; ValueDisplayed: 1.00k
S1 = CMP-0002143-3: Switch, Tactile, SPST-NO, 0.05A, 12V, SMT; Manufacturer: E-Switch; PartNumber: TL1015AF160QG; CurrentRating_mA: 50A; PositionCount: 1; SwitchFunction: Off-Mom; Circuit: SPST-NO; Component Kind: Standard; ComponentLink1Description: Datasheet URL; ComponentLink1URL: http://spec.e-switch.com/P-J/P420000.pdf; CompType: Switch; LatestRevisionDate: 2017-03-09; Library Name: Altium Vault - TI; MountType: SMT; Orientation: Top Actuated; PackageReference: Switch, 4.4x2x2.9 mm; RoHS: Y; Supplier 1: Digi-Key; Supplier 2: Newark; Supplier 3: Mouser; Supplier Part Number 1: EG4344CT-ND; Supplier Part Number 2: 15N4458; Supplier Part Number 3: 612-TL1015AF; VDC_V: 12V; Publisher: Texas Instruments
U19 U20 = CMP-0074888-1: Low-Power, High Supply Range, 60-MHz, Fully Differential Amplifier, DGK0008A (VSSOP-8); Alternate Manufacturer: Texas Instruments; Alternate PartNumber: THS4561IDGKT; CompType: IC; SizeArea_mm2: 15.655; SizeAreaWB_mm2: 35.955; SizeX_mm: 5.05; SizeY_mm: 3.1; SizeZ_mm: 1.1; U/M: EA; Component Kind: Standard; ComponentLink1Description: Datasheet Link; ComponentLink1URL: https://sps06.itg.ti.com/sites/KMS/crossdivteams/altium/docs/ComponentDatasheets/Preview_DS_THS4561.pdf; LatestRevisionDate: 2017-07-11; Library Name: Altium Vault - TI; Manufacturer: Texas Instruments; MountType: SMT; PackageDescription: VSSOP-8; PackageReference: DGK0008A; PartNumber: THS4561IDGKR; Publisher: Texas Instruments
U21 = CMP-0075938-2: 24-Bit, 128kSPS, 4-Ch, Simultaneous Sampling, Delta-Sigma ADC, PBS0032A (TQFP-32); Alternate Manufacturer: Texas Instruments; CompType: IC; SizeArea_mm2: 49.0; SizeAreaWB_mm2: 81.0; SizeX_mm: 7.0; SizeZ_mm: 1.2; U/M: EA; Alternate PartNumber: ADS131A04IPBS; Component Kind: Standard; ComponentLink1Description: Datasheet Link; ComponentLink1URL: http://www.ti.com/lit/ds/symlink/ads131a04.pdf; LatestRevisionDate: 2017-06-09; Library Name: Altium Vault - TI; Manufacturer: Texas Instruments; MountType: SMT; PackageDescription: TQFP-32; PackageReference: PBS0032A; PartNumber: ADS131A04IPBSR; RoHS: Y; SizeY_mm: 7.0; Publisher: Texas Instruments
U22 = CMP-0032584-1: Low Noise, Very Low Drift, Precision Voltage Reference, -40 to 125 degC, 8-pin MSOP(DGK), Green (RoHS & no Sb/Br); Manufacturer: Texas Instruments; PartNumber: REF5025IDGKR; Initial Accuracy @ 25/deg C(%): 0.05; Iout/Iz(Max)(mA): 10; Iq(Max)(uA): 1000; Rating: Military; SubFamily: Series Voltage Reference; Temp Coeff(Max)(ppm/ degree C): 3; VI(Max)(V): 18; VI(Min)(V): 2.7; VO(V): 2.5; Code_JEDEC: MO-187; Component Kind: Standard; ComponentLink1Description: Manufacturer URL; ComponentLink1URL: http://www.ti.com/; ComponentLink2Description: Datasheet; ComponentLink2URL: http://www.ti.com/general/docs/lit/getliterature.tsp?genericPartNumber=REF5025&fileType=pdf; ComponentLink3Description: Package Specification; ComponentLink3URL: http://www.ti.com/litv/pdf/mpds028d; DatasheetVersion: SBOS410E; Eco-Plan: Green (RoHS & no Sb/Br); Library Name: Altium Vault - TI; Mounting Technology: Surface Mount; PackageDescription: 8-Pin Thin Small Outline Package 3 x 3 mm Body ,0.65 mm Pitch; PackageReference: DGK0008A; PackageVersion: 4073329/E
VREF_ADC = PogoPad: Pad for Pogo Pin Connector; SymbolCreator: codlsc; CompType: Pogo Pad; LatestRevisionDate: 2016-12-05; LatestRevisionNote: Added Alternate PartNumber and Alternate Manufacturer parameters to appropriate components.; Library Name: MiscParts.IntLib; PackageReference: N/A; SymbolVersion: 2010-09-27; Publisher: Texas Instruments
Y1 = CMP-0004606-3: Crystal, 16.384 MHz, 20 pF, SMD; Manufacturer: CTS Electrocomponents; PartNumber: 407F35E016M3840; Frequency Stability: +/-50ppm; TaMax_C: 70°C; TaMin_C: -20°C; Component Kind: Standard; ComponentLink1Description: Datasheet Link; ComponentLink1URL: http://www.ctscorp.com/components/Datasheets/008-0261-0_G.pdf; CompType: Crystal; Frequency_Fixed: 16.384MHz; Library Name: Altium Vault - TI; MountType: SMT; PackageReference: SMD, 4-Leads, Body 7.2x5.2mm; RoHS: Y; Supplier 1: Digi-Key; Supplier Part Number 1: CTX682CT-ND
